# Altium schematic sheet MCU.SchDoc(MCU)
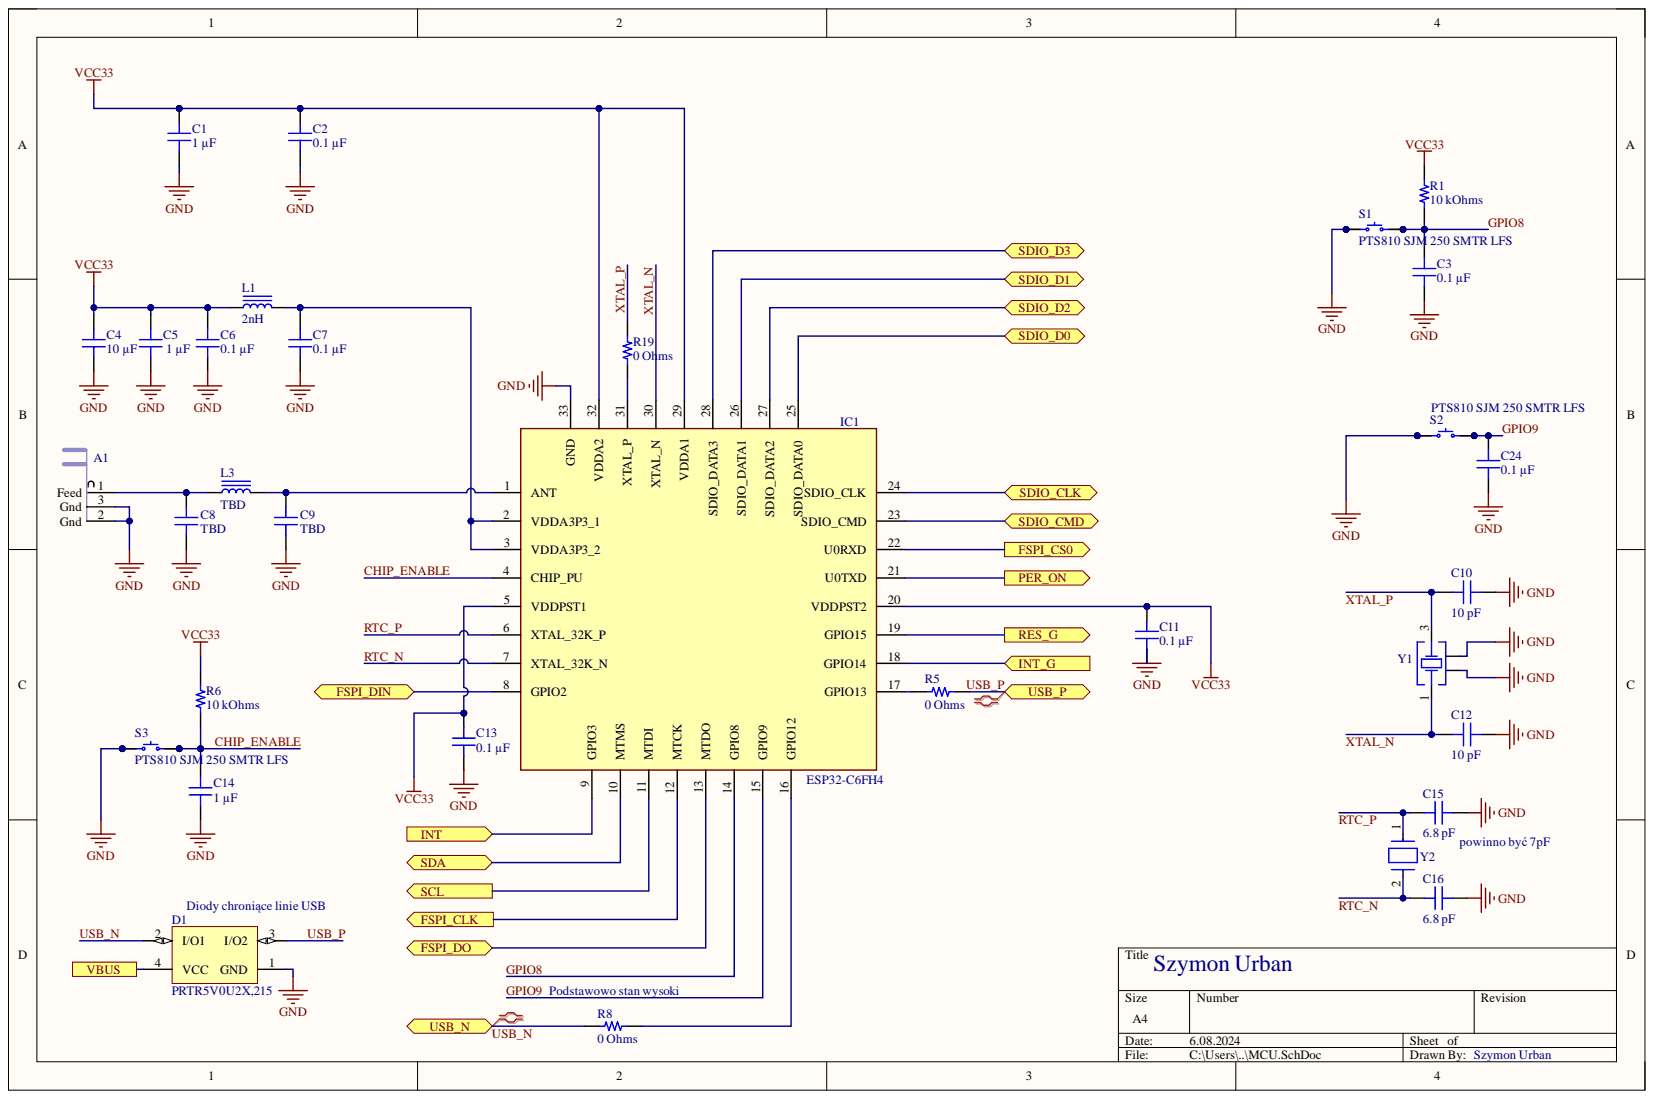
[diagram p0: MCU.SchDoc]

## Parts
A1  Inverted F Antenna 2.4GHz  [IFA_2.4GHz]  (value not drawn)
C1  1 µF  [CAP 0603_1608]
C2  0.1 µF  [CAP 0603_1608]
C3  0.1 µF  [CAP 0603_1608]
C4  10 µF  [CAP 0603_1608]
C5  1 µF  [CAP 0603_1608]
C6  0.1 µF  [CAP 0603_1608]
C7  0.1 µF  [CAP 0603_1608]
C8  TBD  [CAP 0402_1005]
C9  TBD  [CAP 0402_1005]
C10  10 pF  [CAP 0603_1608]
C11  0.1 µF  [CAP 0603_1608]
C12  10 pF  [CAP 0603_1608]
C13  0.1 µF  [CAP 0603_1608]
C14  1 µF  [CAP 0603_1608]
C15  6.8 pF  [CAP 0603_1608]
C16  6.8 pF  [CAP 0603_1608]
C24  0.1 µF  [CAP 0603_1608]
D1  PRTR5V0U2X,215  [NXP-SOT143B-4_V]
IC1  ESP32-C6FH4  [QFN50P500X500X90-33N]
L1  2nH  [IND 0603_1608 RF WW]
L3  TBD  [IND 0402_1005 RF WW]
R1  10 kOhms  [RES 0603_1608]
R5  0 Ohms  [RES 0603_1608]
R6  10 kOhms  [RES 0603_1608]
R8  0 Ohms  [RES 0603_1608]
R19  0 Ohms  [RES 0603_1608]
S1  PTS810 SJM 250 SMTR LFS  Series: PTS810  [C&K PTS810]
S2  PTS810 SJM 250 SMTR LFS  Series: PTS810  [C&K PTS810]
S3  PTS810 SJM 250 SMTR LFS  Series: PTS810  [C&K PTS810]
Y1  830108208709  [WE-XTAL_CFPX-218]  (value not drawn)
Y2  ABS07-32.768KHZ-7-1-T  [FP-ABS07-MFG]  (value not drawn)

## Ports
FSPI_CLK, FSPI_CS0, FSPI_DIN, FSPI_DO, INT, INT_G, PER_ON, RES_G, SCL, SDA, SDIO_CLK, SDIO_CMD, SDIO_D0, SDIO_D1, SDIO_D2, SDIO_D3, USB_N, USB_P, VBUS

## Nets
GND: A1.2 A1.3 C1.1 C2.1 C3.1 C4.2 C5.1 C6.1 C7.1 C8.1 C9.1 C10.2 C11.1 C12.2 C13.1 C14.1 C15.2 C16.2 C24.1 D1.1(GND) IC1.33(GND) S1.1 S1.2 S2.1 S2.2 S3.1 S3.2 Y1.2 Y1.4
VCC33: C1.2 C2.2 C4.1 C5.2 C6.2 C11.2 C13.2 IC1.5(VDDPST1) IC1.20(VDDPST2) IC1.29(VDDA1) IC1.32(VDDA2) L1.1 R1.2 R6.2
CHIP_ENABLE: C14.2 IC1.4(CHIP_PU) R6.1 S3.3 S3.4
FSPI_CLK: IC1.12(MTCK) ; port FSPI_CLK
FSPI_CS0: IC1.22(U0RXD) ; port FSPI_CS0
FSPI_DIN: IC1.8(GPIO2) ; port FSPI_DIN
FSPI_DO: IC1.13(MTDO) ; port FSPI_DO
GPIO8: C3.2 IC1.14(GPIO8) R1.1 S1.3 S1.4
GPIO9: C24.2 IC1.15(GPIO9) S2.3 S2.4
INT: IC1.9(GPIO3) ; port INT
INT_G: IC1.18(GPIO14) ; port INT_G
PER_ON: IC1.21(U0TXD) ; port PER_ON
RES_G: IC1.19(GPIO15) ; port RES_G
RTC_N: C16.1 IC1.7(XTAL_32K_N) Y2.2
RTC_P: C15.1 IC1.6(XTAL_32K_P) Y2.1
SCL: IC1.11(MTDI) ; port SCL
SDA: IC1.10(MTMS) ; port SDA
SDIO_CLK: IC1.24(SDIO_CLK) ; port SDIO_CLK
SDIO_CMD: IC1.23(SDIO_CMD) ; port SDIO_CMD
SDIO_D0: IC1.25(SDIO_DATA0) ; port SDIO_D0
SDIO_D1: IC1.26(SDIO_DATA1) ; port SDIO_D1
SDIO_D2: IC1.27(SDIO_DATA2) ; port SDIO_D2
SDIO_D3: IC1.28(SDIO_DATA3) ; port SDIO_D3
USB_N: D1.2(I/O1) R8.2 ; port USB_N
USB_P: D1.3(I/O2) R5.1 ; port USB_P
VBUS: D1.4(VCC) ; port VBUS
XTAL_N: C12.1 IC1.30(XTAL_N) Y1.1
XTAL_P: C10.1 R19.1 Y1.3
NetA1_1: A1.1 C8.2 L3.1
NetC7_2: C7.2 IC1.2(VDDA3P3_1) IC1.3(VDDA3P3_2) L1.2
NetC9_2: C9.2 IC1.1(ANT) L3.2
NetIC1_16: IC1.16(GPIO12) R8.1
NetIC1_17: IC1.17(GPIO13) R5.2
NetIC1_31: IC1.31(XTAL_P) R19.2

## Library data of the parts
A1 = IFA_2.4GHz: Component Kind: Net Tie; Library Name: lib.SchLib
C1 C5 C14 = CC0603KRX7R6BB105: CAP CER 1UF 10V X7R 0603; manufacturer: Yageo; Database Table Name: Capacitors - Ceramic - 0603; Tolerance: ±10%; Applications: General Purpose; Capacitance: 1 µF; Component Kind: Standard; componentlink1description: Datasheet; componentlink1url: https://www.yageo.com/upload/media/product/productsearch/datasheet/mlcc/UPY-GPHC_X7R_6.3V-to-50V_18.pdf; componentlink2description: DigiKey Link; componentlink2url: https://www.digikey.com/en/products/detail/yageo/CC0603KRX7R6BB105/5195204; Device Package: 0603 (1608 Metric); lastupdated: 15.01.2024 20:10:05; Library Name: Celestial Altium Library - altium_library.DbLib; Lifecycle Status: Active; Minimum Order: 1; Mounting Type: Surface Mount, MLCC; Operating Temperature: -55°C ~ 125°C; Package / Case: 0603 (1608 Metric); packaging: TapeAndReel; Part Number: CC0603KRX7R6BB105; Part Status: Active; partid: 2290; price: 0.01; series: CC; Size / Dimension: 0.063" L x 0.031" W (1.60mm x 0.80mm); Supplier 1: DigiKey; Supplier Part Number 1: 311-1796-1-ND; Temperature Coefficient: X7R; Thickness (Max): 0.035" (0.90mm); Value: 1 µF; Voltage - Rated: 10V
C2 C3 C6 C7 C11 C13 C24 = CS0603KRX7R7BB104: CAP CER 0.1UF 16V X7R 0603; manufacturer: Yageo; Database Table Name: Capacitors - Ceramic - 0603; Tolerance: ±10%; Applications: Boardflex Sensitive; Capacitance: 0.1 µF; Component Kind: Standard; componentlink1description: Datasheet; componentlink1url: http://www.yageo.com/NewPortal/yageodocoutput?fileName=/pdf/MLCC/UPY-ST_NPOX7R_16V-to-3KV_5.pdf; componentlink2description: DigiKey Link; componentlink2url: https://www.digikey.com/en/products/detail/yageo/CS0603KRX7R7BB104/6097897; Device Package: 0603 (1608 Metric); Features: Soft Termination; lastupdated: 15.01.2024 20:10:05; Library Name: Celestial Altium Library - altium_library.DbLib; Lifecycle Status: Active; Minimum Order: 1; Mounting Type: Surface Mount, MLCC; Operating Temperature: -55°C ~ 125°C; Package / Case: 0603 (1608 Metric); packaging: TapeAndReel; Part Number: CS0603KRX7R7BB104; Part Status: Active; partid: 2286; price: 0.01; series: CS; Size / Dimension: 0.063" L x 0.031" W (1.60mm x 0.80mm); Supplier 1: DigiKey; Supplier Part Number 1: 311-3560-1-ND; Temperature Coefficient: X7R; Thickness (Max): 0.037" (0.95mm); Value: 0.1 µF; Voltage - Rated: 16V
C4 = GRM188D71A106KA73D: CAP CER 10UF 10V X7T 0603; manufacturer: Murata Electronics; Database Table Name: Capacitors - Ceramic - 0603; Tolerance: ±10%; Applications: General Purpose; Capacitance: 10 µF; Component Kind: Standard; componentlink1description: Datasheet; componentlink1url: https://search.murata.co.jp/Ceramy/image/img/A01X/G101/ENG/GRM188D71A106KA73-01.pdf; componentlink2description: DigiKey Link; componentlink2url: https://www.digikey.com/en/products/detail/murata-electronics/GRM188D71A106KA73D/6606090; Device Package: 0603 (1608 Metric); lastupdated: 15.01.2024 20:10:04; Library Name: Celestial Altium Library - altium_library.DbLib; Lifecycle Status: Active; Minimum Order: 1; Mounting Type: Surface Mount, MLCC; Operating Temperature: -55°C ~ 125°C; Package / Case: 0603 (1608 Metric); packaging: TapeAndReel; Part Number: GRM188D71A106KA73D; Part Status: Active; partid: 2489; price: 0.03; series: GRM; Size / Dimension: 0.063" L x 0.031" W (1.60mm x 0.80mm); Supplier 1: DigiKey; Supplier Part Number 1: 490-14461-1-ND; Temperature Coefficient: X7T; Thickness (Max): 0.039" (1.00mm); Value: 10 µF; Voltage - Rated: 10V
C8 C9 = 04023A6R8CAT2A: CAP CER 6.8PF 25V C0G/NP0 0402; manufacturer: AVX Corporation; Database Table Name: Capacitors - Ceramic - 0402; Temperature Coefficient: C0G, NP0; Tolerance: ±0.25pF; Applications: General Purpose; Component Kind: Standard; componentlink1description: Datasheet; componentlink1url: http://datasheets.avx.com/C0GNP0-Dielectric.pdf; componentlink2description: DigiKey Link; componentlink2url: http://digikey.com/product-detail/en/avx-corporation/04023A6R8CAT2A/478-12617-1-ND/9949155; Device Package: 0402 (1005 Metric); lastupdated: 08.02.2022 12:26:50; Library Name: Celestial Altium Library - altium_library.DbLib; Lifecycle Status: Active; Minimum Order: 1; Mounting Type: Surface Mount, MLCC; Operating Temperature: -55°C ~ 125°C; Package / Case: 0402 (1005 Metric); packaging: TapeAndReel; Part Number: 04023A6R8CAT2A; Part Status: Active; partid: 6987; price: 0.06; series: -; Size / Dimension: 0.039" L x 0.020" W (1.00mm x 0.50mm); Supplier 1: DigiKey; Supplier Part Number 1: 478-12617-1-ND; Thickness (Max): 0.022" (0.56mm); Value: TBD; Voltage - Rated: 25V
  C8: Capacitance: TBD
  C9: Capacitance: 6.8pF
C10 C12 = VJ0603A100FXACW1BC: CAP CER 10PF 50V C0G/NP0 0603; manufacturer: Vishay Vitramon; Database Table Name: Capacitors - Ceramic - 0603; Temperature Coefficient: C0G, NP0; Tolerance: ±1%; Applications: General Purpose; Capacitance: 10 pF; Component Kind: Standard; componentlink1description: Datasheet; componentlink1url: https://www.vishay.com/docs/28548/vjw1bcbascomseries.pdf; componentlink2description: DigiKey Link; componentlink2url: https://www.digikey.com/en/products/detail/vishay-vitramon/VJ0603A100FXACW1BC/8302770; Device Package: 0603 (1608 Metric); lastupdated: 15.01.2024 20:09:38; Library Name: Celestial Altium Library - altium_library.DbLib; Lifecycle Status: Active; Minimum Order: 1; Mounting Type: Surface Mount, MLCC; Operating Temperature: -55°C ~ 125°C; Package / Case: 0603 (1608 Metric); packaging: TapeAndReel; Part Number: VJ0603A100FXACW1BC; Part Status: Active; partid: 42121; price: 0.01; series: VJ W1BC; Size / Dimension: 0.063" L x 0.031" W (1.60mm x 0.80mm); Supplier 1: DigiKey; Supplier Part Number 1: 720-VJ0603A100FXACW1BCCT-ND; Thickness (Max): 0.034" (0.87mm); Value: 10 pF; Voltage - Rated: 50V
C15 C16 = GQM1875C2E6R8WB12D: CAP CER 6.8PF 250V C0G/NP0 0603; manufacturer: Murata Electronics; Database Table Name: Capacitors - Ceramic - 0603; Temperature Coefficient: C0G, NP0; Tolerance: ±0.05pF; Applications: RF, Microwave, High Frequency; Capacitance: 6.8 pF; Component Kind: Standard; componentlink1description: Datasheet; componentlink1url: https://search.murata.co.jp/Ceramy/image/img/A01X/G101/ENG/GQM1875C2E6R8WB12-01.pdf; componentlink2description: DigiKey Link; componentlink2url: https://www.digikey.com/en/products/detail/murata-electronics/GQM1875C2E6R8WB12D/16033646; Device Package: 0603 (1608 Metric); Features: High Q, Low Loss; lastupdated: 15.01.2024 20:09:09; Library Name: Celestial Altium Library - altium_library.DbLib; Lifecycle Status: Active; Minimum Order: 1; Mounting Type: Surface Mount, MLCC; Operating Temperature: -55°C ~ 125°C; Package / Case: 0603 (1608 Metric); packaging: TapeAndReel; Part Number: GQM1875C2E6R8WB12D; Part Status: Active; partid: 50061; price: 0.11; series: GQM; Size / Dimension: 0.063" L x 0.031" W (1.60mm x 0.80mm); Supplier 1: DigiKey; Supplier Part Number 1: 490-GQM1875C2E6R8WB12DCT-ND; Thickness (Max): 0.031" (0.80mm); Value: 6.8 pF; Voltage - Rated: 250V
D1 = CMP-2000-05285-1: Ultra Low Capacitance Double Rail-to-Rail ESD Protection Diode, 5.5 V, 1 pF, -40 to 85 degC, 4-Pin SOT143B, RoHS, Tape and Reel; Manufacturer: NXP Semiconductors; Capacitance Value: 16pF; Configuration: Quad; Direction Type: Uni-Directional; ESD Protection Voltage: 8KV@ContactDisc; Leakage Current: 0.1uA; Number of Elements: 1; Product Depth (mm): 1.4mm; Product Height (mm): 1mm; Product Length (mm): 3mm; Rad Hardened: No; Reverse Breakdown Voltage: 6V; Reverse Stand-off Voltage: 5.5V; Suppressor Type: DiodeArrays; Component Kind: Standard; Datasheet URL: https://datasheet.ciiva.com/4479/prtr5v0u2x-102853-4479461.pdf; Datasheet Version: Rev. 02, 01/2008; Leakage Current (Max): 0.1uA; Library Name: Altium Content Vault; Manufacturer URL: http://www.nxp.com/; Mounting: SurfaceMount; Mounting Style: SurfaceMount; Operating Temp Range: -40Cto85C; Operating Temperature Classification: Industrial; Operating Temperature Max Deg. C: 85C; Operating Temperature Min Deg. C: -40C; Package / Case: SOT; Package Type: SOT; Packaging: TapeandReel; Pin Count: 3+Tab; Polarity: Uni-Directional; Working Voltage: 5.5V; Working Voltage (Max): 5.5V
IC1 = ESP32-C6FH4: Integrated Circuit; Manufacturer_Name: Espressif Systems; Component Kind: Standard; Datasheet Link: https://www.mouser.ch/ProductDetail/Espressif-Systems/ESP32-C6FH4?qs=sGAEpiMZZMu3sxpa5v1qriveB5Rxa06Y9iJOmwW58jU%3D; Height: 0.9mm; Library Name: lib.SchLib; Manufacturer_Part_Number: ESP32-C6FH4; Mouser Part Number: 356-ESP32-C6FH4; Mouser Price/Stock: https://www.mouser.co.uk/ProductDetail/Espressif-Systems/ESP32-C6FH4?qs=Imq1NPwxi77uZvg%252BuAIiXA%3D%3D
L1 = 744761020A: FIXED IND 2NH 700MA 80 MOHM SMD; Manufacturer: Würth Elektronik; Database Table Name: Inductors - RF; DC Resistance (DCR): 80mOhm Max; Frequency - Self Resonant: 6.9GHz; Inductance Frequency - Test: 250MHz; Material - Core: Ceramic; Q @ Freq: 16 @ 250MHz; Shielding: Unshielded; Tolerance: ±0.2nH; Type: Wirewound; Component Kind: Standard; ComponentLink1Description: Datasheet; ComponentLink1URL: https://katalog.we-online.com/pbs/datasheet/744761020A.pdf; ComponentLink2Description: DigiKey Link; ComponentLink2URL: http://digikey.com/product-detail/en/w-rth-elektronik/744761020A/732-744761020ACT-ND/10668665; Current Rating (Amps): 700mA; Device Package: 0603 (1608 Metric); Inductance: 2nH; LastUpdated: 06.09.2020 11:05:18; Library Name: Celestial Altium Library - altium_library.DbLib; Lifecycle Status: Active; Minimum Order: 1; Mounting Type: Surface Mount; Operating Temperature: -40°C ~ 125°C; Package / Case: 0603 (1608 Metric); Packaging: Unknown; Part Number: 744761020A; Part Status: Active; PartId: 1038; Price: 0.18; Series: WE-KI; Supplier 1: DigiKey; Supplier Device Package: 0603; Supplier Part Number 1: 732-744761020ACT-ND; Value: 2nH
L3 = LQW18ASR39J00D: FIXED IND 390NH 100MA 4.35OHM SM; Manufacturer: Murata Electronics; Database Table Name: Inductors - RF; DC Resistance (DCR): 4.35Ohm Max; Frequency - Self Resonant: 900MHz; Inductance Frequency - Test: 100 MHz; Material - Core: Non-Magnetic; Q @ Freq: 25 @ 100MHz; Shielding: Unshielded; Tolerance: ±5%; Type: Wirewound; Component Kind: Standard; ComponentLink2Description: DigiKey Link; ComponentLink2URL: https://www.digikey.com/en/products/detail/murata-electronics/LQW18ASR39J00D/10702651; Current Rating (Amps): 100 mA; Device Package: 0603 (1608 Metric); Height - Seated (Max): 0.039" (1.00mm); Inductance: 390 nH; LastUpdated: 15.01.2024 21:33:43; Library Name: Celestial Altium Library - altium_library.DbLib; Lifecycle Status: Active; Minimum Order: 1; Mounting Type: Surface Mount; Operating Temperature: -40°C ~ 125°C; Package / Case: 0603 (1608 Metric); Packaging: TapeAndReel; Part Number: LQW18ASR39J00D; Part Status: Active; PartId: 1604; Price: 0.05; Series: LQW15; Size / Dimension: 0.063" L x 0.031" W (1.60mm x 0.80mm); Supplier 1: DigiKey; Supplier Device Package: 0603; Supplier Part Number 1: 490-LQW18ASR39J00DCT-ND; Value: TBD
R1 R6 = RT0603FRE0710KL: RES SMD 10K OHM 1% 1/10W 0603; Manufacturer: Yageo; Database Table Name: Resistors - Surface Mount - 0603; Power (Watts): 0.1W, 1/10W; Temperature Coefficient: ±50ppm/°C; Component Kind: Standard; ComponentLink1Description: Datasheet; ComponentLink1URL: https://www.yageo.com/upload/media/product/productsearch/datasheet/rchip/PYu-RT_1-to-0.01_RoHS_L_11.pdf; ComponentLink2Description: DigiKey Link; ComponentLink2URL: https://www.digikey.com/en/products/detail/yageo/RT0603FRE0710KL/1075040; Composition: Thin Film; Device Package: 0603 (1608 Metric); Height - Seated (Max): 0.022" (0.55mm); LastUpdated: 15.01.2024 20:40:25; Library Name: Celestial Altium Library - altium_library.DbLib; Lifecycle Status: Active; Minimum Order: 1; Number of Terminations: 2; Operating Temperature: -55°C ~ 155°C; Package / Case: 0603 (1608 Metric); Packaging: TapeAndReel; Part Number: RT0603FRE0710KL; Part Status: Active; PartId: 5671; Price: 0.01; Resistance: 10 kOhms; Series: RT; Size / Dimension: 0.063" L x 0.031" W (1.60mm x 0.80mm); Supplier 1: DigiKey; Supplier Device Package: 0603; Supplier Part Number 1: YAG2321CT-ND; Tolerance: ±1%; Value: 10 kOhms
R5 R8 R19 = JR0603X26E: 0603 26 AMP JUMPER; Manufacturer: Ohmite; Database Table Name: Resistors - Surface Mount - 0603; Power (Watts): 0.135W; Component Kind: Standard; ComponentLink1Description: Datasheet; ComponentLink1URL: https://www.ohmite.com/assets/docs/res_jr.pdf?r=false; ComponentLink2Description: DigiKey Link; ComponentLink2URL: https://www.digikey.com/en/products/detail/ohmite/JR0603X26E/10233371; Composition: Metal Element; Device Package: 0603 (1608 Metric); Height - Seated (Max): 0.022" (0.55mm); LastUpdated: 15.01.2024 20:40:20; Library Name: Celestial Altium Library - altium_library.DbLib; Lifecycle Status: Active; Minimum Order: 1; Number of Terminations: 2; Operating Temperature: -55°C ~ 150°C; Package / Case: 0603 (1608 Metric); Packaging: TapeAndReel; Part Number: JR0603X26E; Part Status: Active; PartId: 6812; Price: 0.10; Resistance: 0 Ohms; Series: JR; Size / Dimension: 0.063" L x 0.031" W (1.60mm x 0.80mm); Supplier 1: DigiKey; Supplier Device Package: 0603; Supplier Part Number 1: JR0603X26ECT-ND; Tolerance: Jumper; Value: 0 Ohms
S1-S3 = PTS810 SJM 250 SMTR LFS: SWITCH TACTILE SPST-NO 0.05A 16V; Manufacturer: C&K; Actuator Height off PCB, Vertical: 2.50mm; Actuator Orientation: Top Actuated; Actuator Type: Oval Button; Contact Rating @ Voltage: 0.05A @ 16VDC; Database Table Name: Switches - Tactile; Illumination: Non-Illuminated; Operating Force: 160gf; Outline: 4.20mm x 3.20mm; Switch Function: Off-Mom; Circuit: SPST-NO; Component Kind: Standard; ComponentLink1Description: Datasheet; ComponentLink1URL: https://dznh3ojzb2azq.cloudfront.net/products/Tactile/PTS810/documents/datasheet.pdf; ComponentLink2Description: DigiKey Link; ComponentLink2URL: http://digikey.com/product-detail/en/c-k/PTS810-SJM-250-SMTR-LFS/CKN10502CT-ND/4176673; LastUpdated: 09.04.2020 10:00:13; Library Name: Celestial Altium Library - altium_library.DbLib; Lifecycle Status: Active; Minimum Order: 1; Mounting Type: Surface Mount; Operating Temperature: -40°C ~ 85°C; Packaging: TapeAndReel; Part Number: PTS810 SJM 250 SMTR LFS; Part Status: Active; Price: 0.16; Supplier 1: DigiKey; Supplier Part Number 1: CKN10502CT-ND; Termination Style: J Lead
Y1 = CMP-03913-116855769-1: 830108208709 WE-XTAL Quartz Crystal; Frequency: 40MHz; Component Kind: Standard; Height: 600µm; Length: 2.5mm; Library Name: Altium Content Vault; Max Operating Temperature: 70°C; Min Operating Temperature: -20°C; NexarPartId: 116855769; Width: 2mm
Y2 = CMP-27762-015873-1: Crystal 32.768kHz ±10ppm (Tol) 7pF FUND 70kΩ 2-Pin CSMD T/R; ESR (Equivalent Series Resistance): 70kR; Case/Package: SMD/SMT; Component Kind: Standard; Frequency: 32.768kHz; Frequency Tolerance: 10ppm; Height: 0.035inch; Lead Free: Lead Free; Length: 0.126inch; Library Name: Altium Content Vault; Load Capacitance: 7pF; Max Operating Temperature: 85°C; Min Operating Temperature: -40°C; Mount: Surface Mount; Operating Frequency: 32.768 kHz; Packaging: Cut Tape; RoHS Compliant: Yes; Series: ABS07; Width: 0.061inch
